# Altium schematic sheet 17-DPLL Outputs and Inputs.SchDoc("17-DPLL Outputs and Inputs")
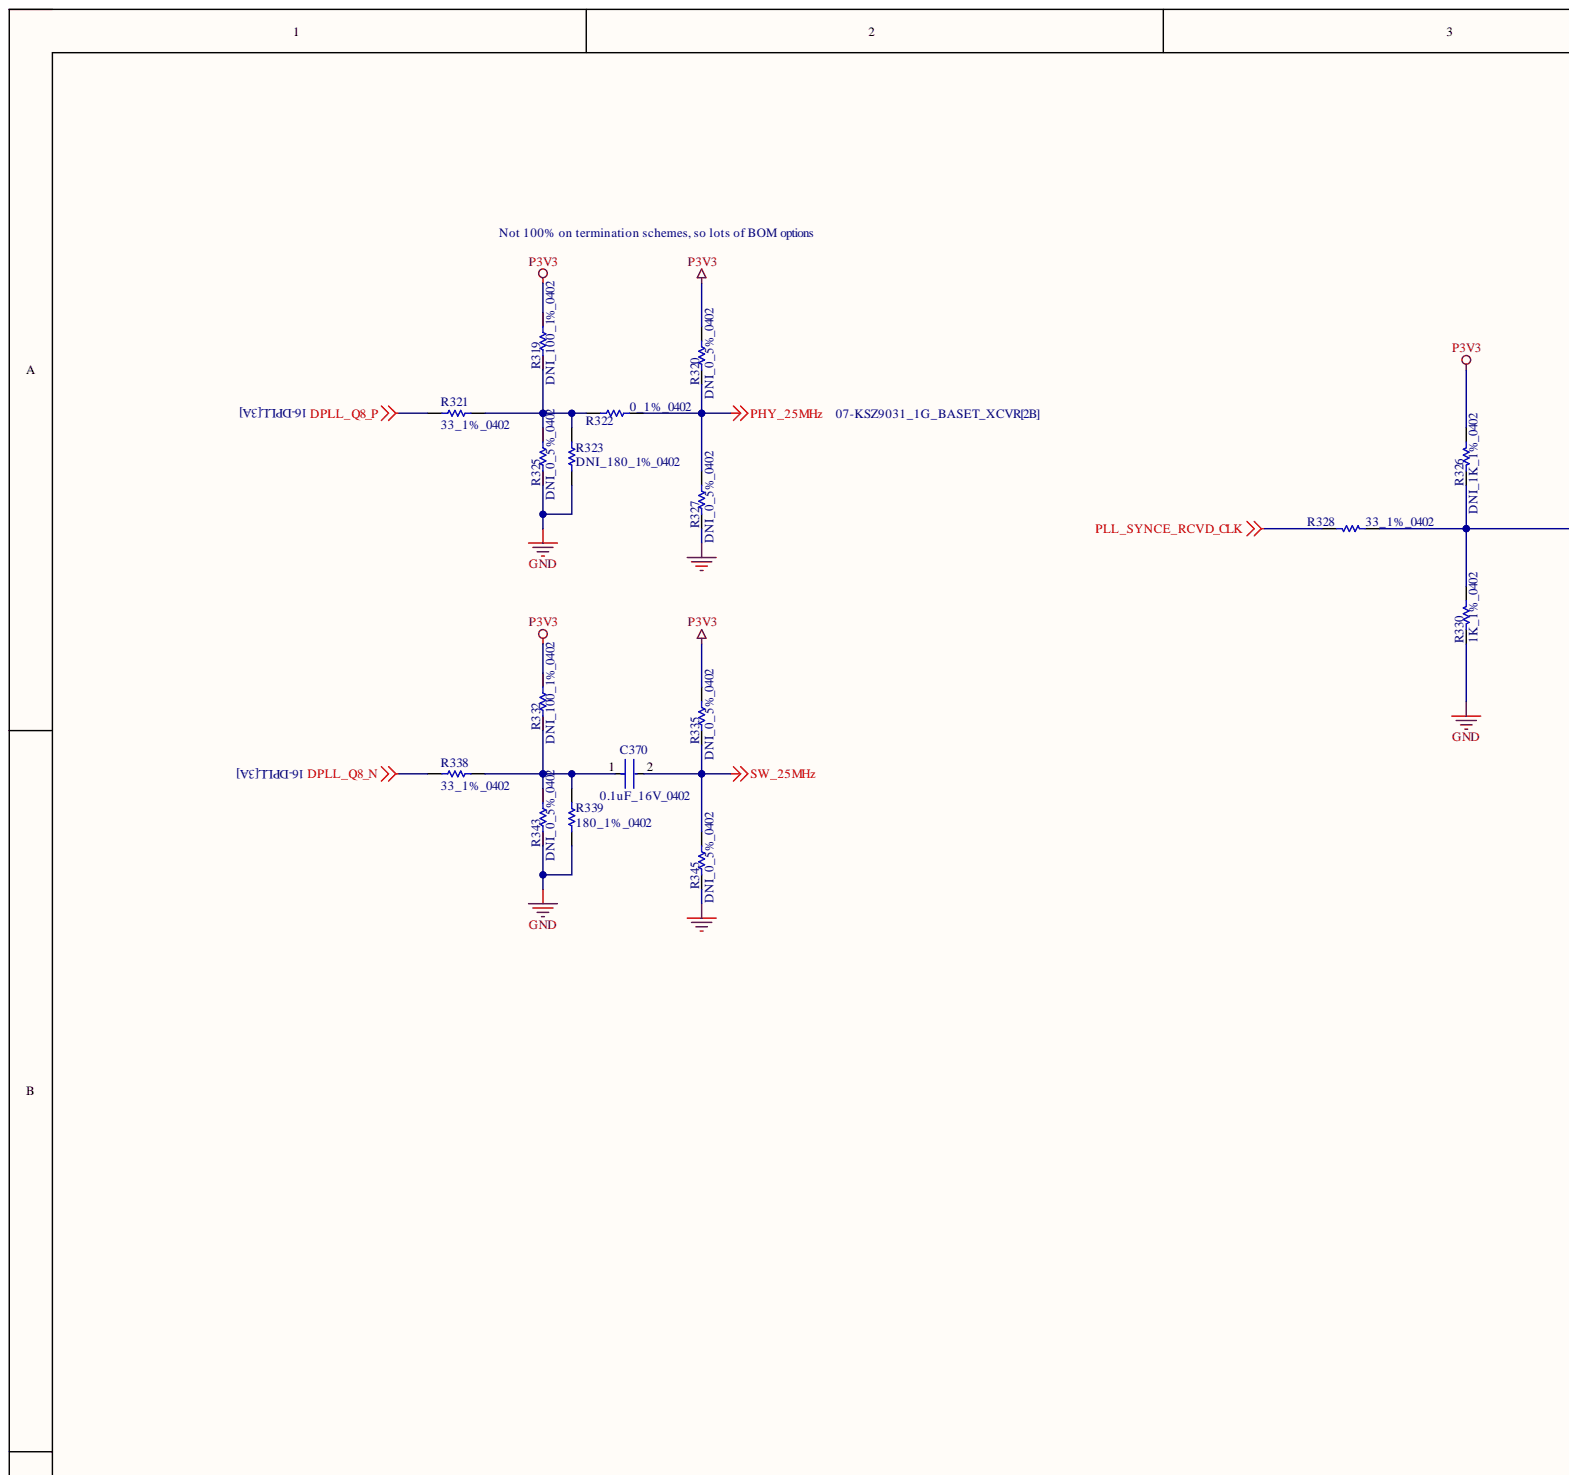
[diagram p0: 17-DPLL Outputs and Inputs.SchDoc, top left]
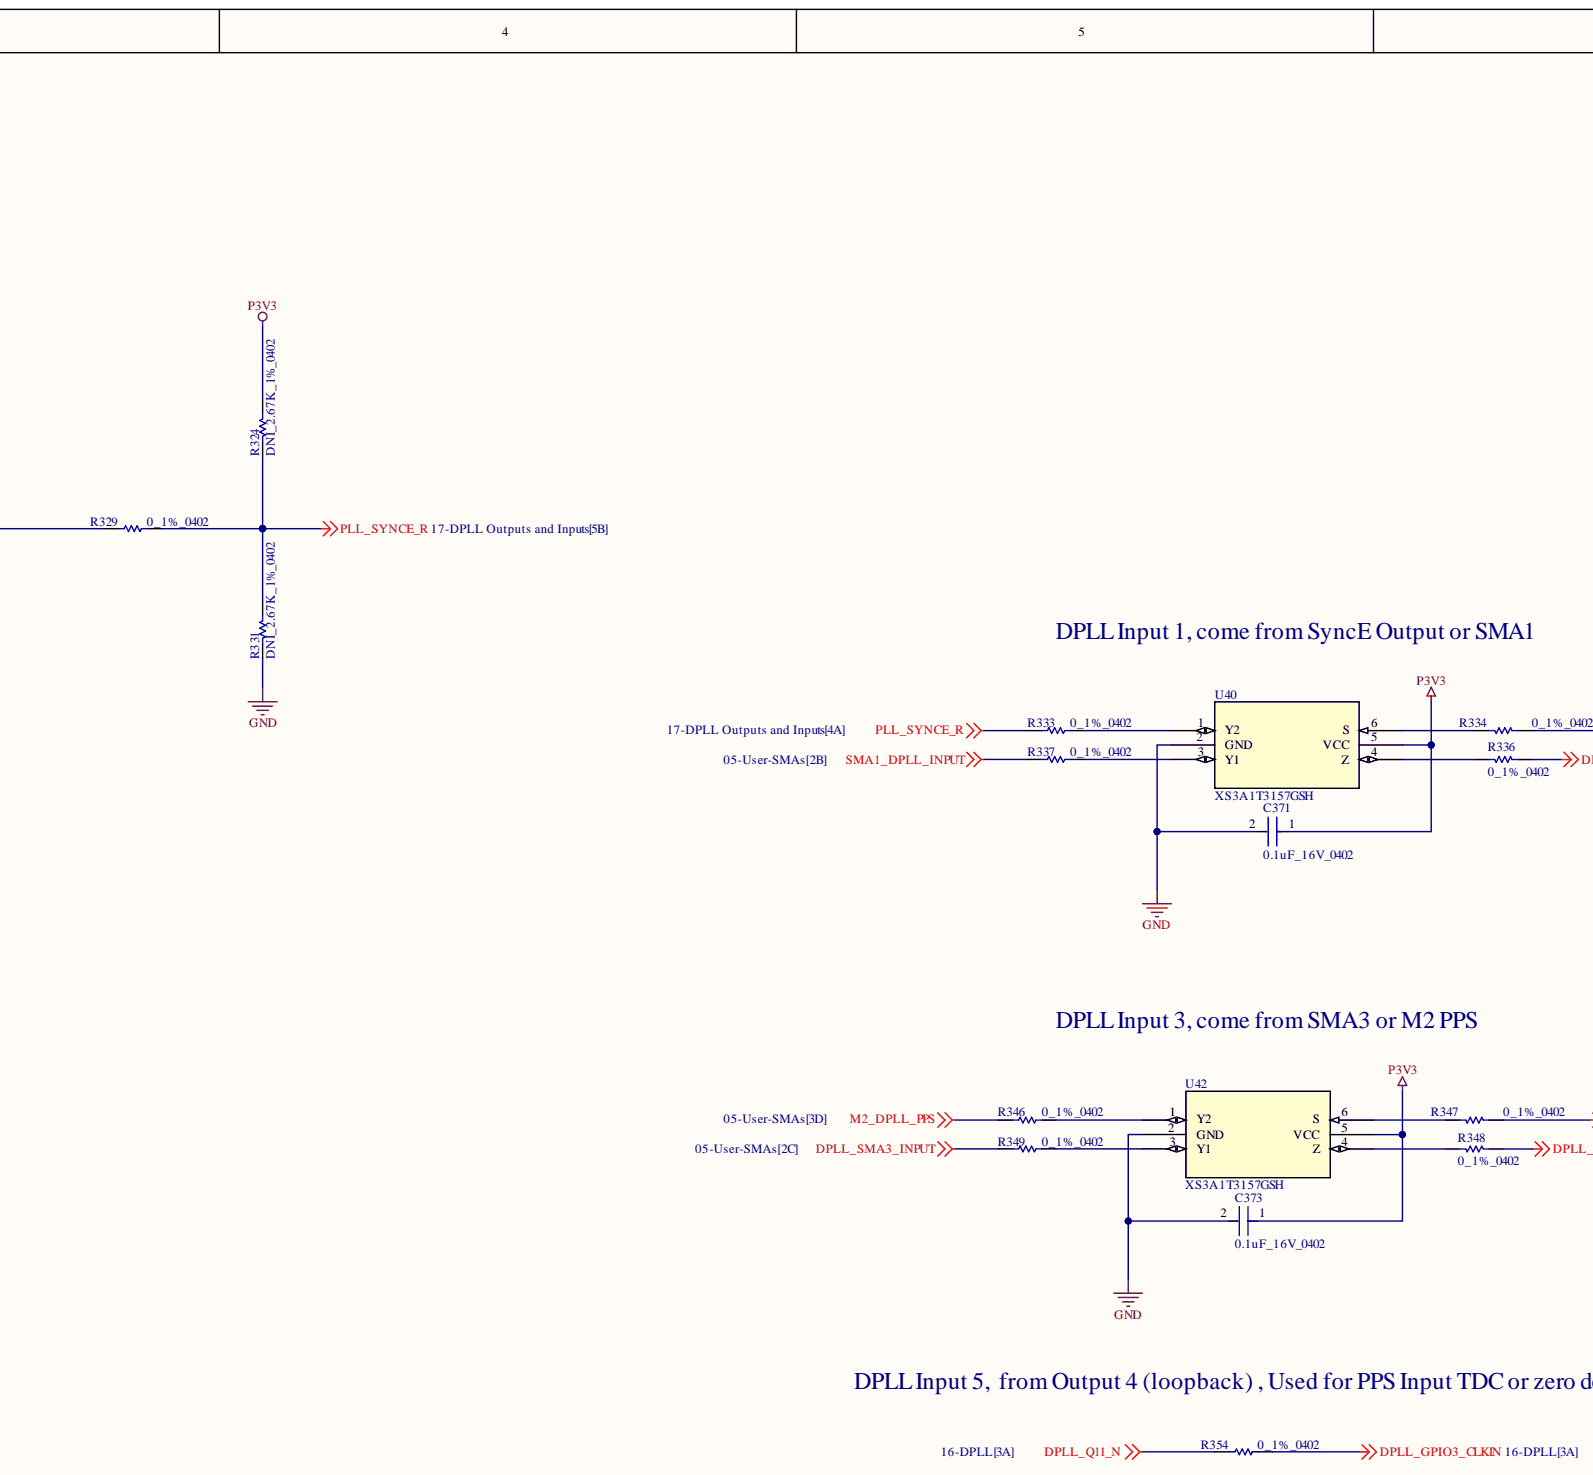
[diagram p1: 17-DPLL Outputs and Inputs.SchDoc, top centre]
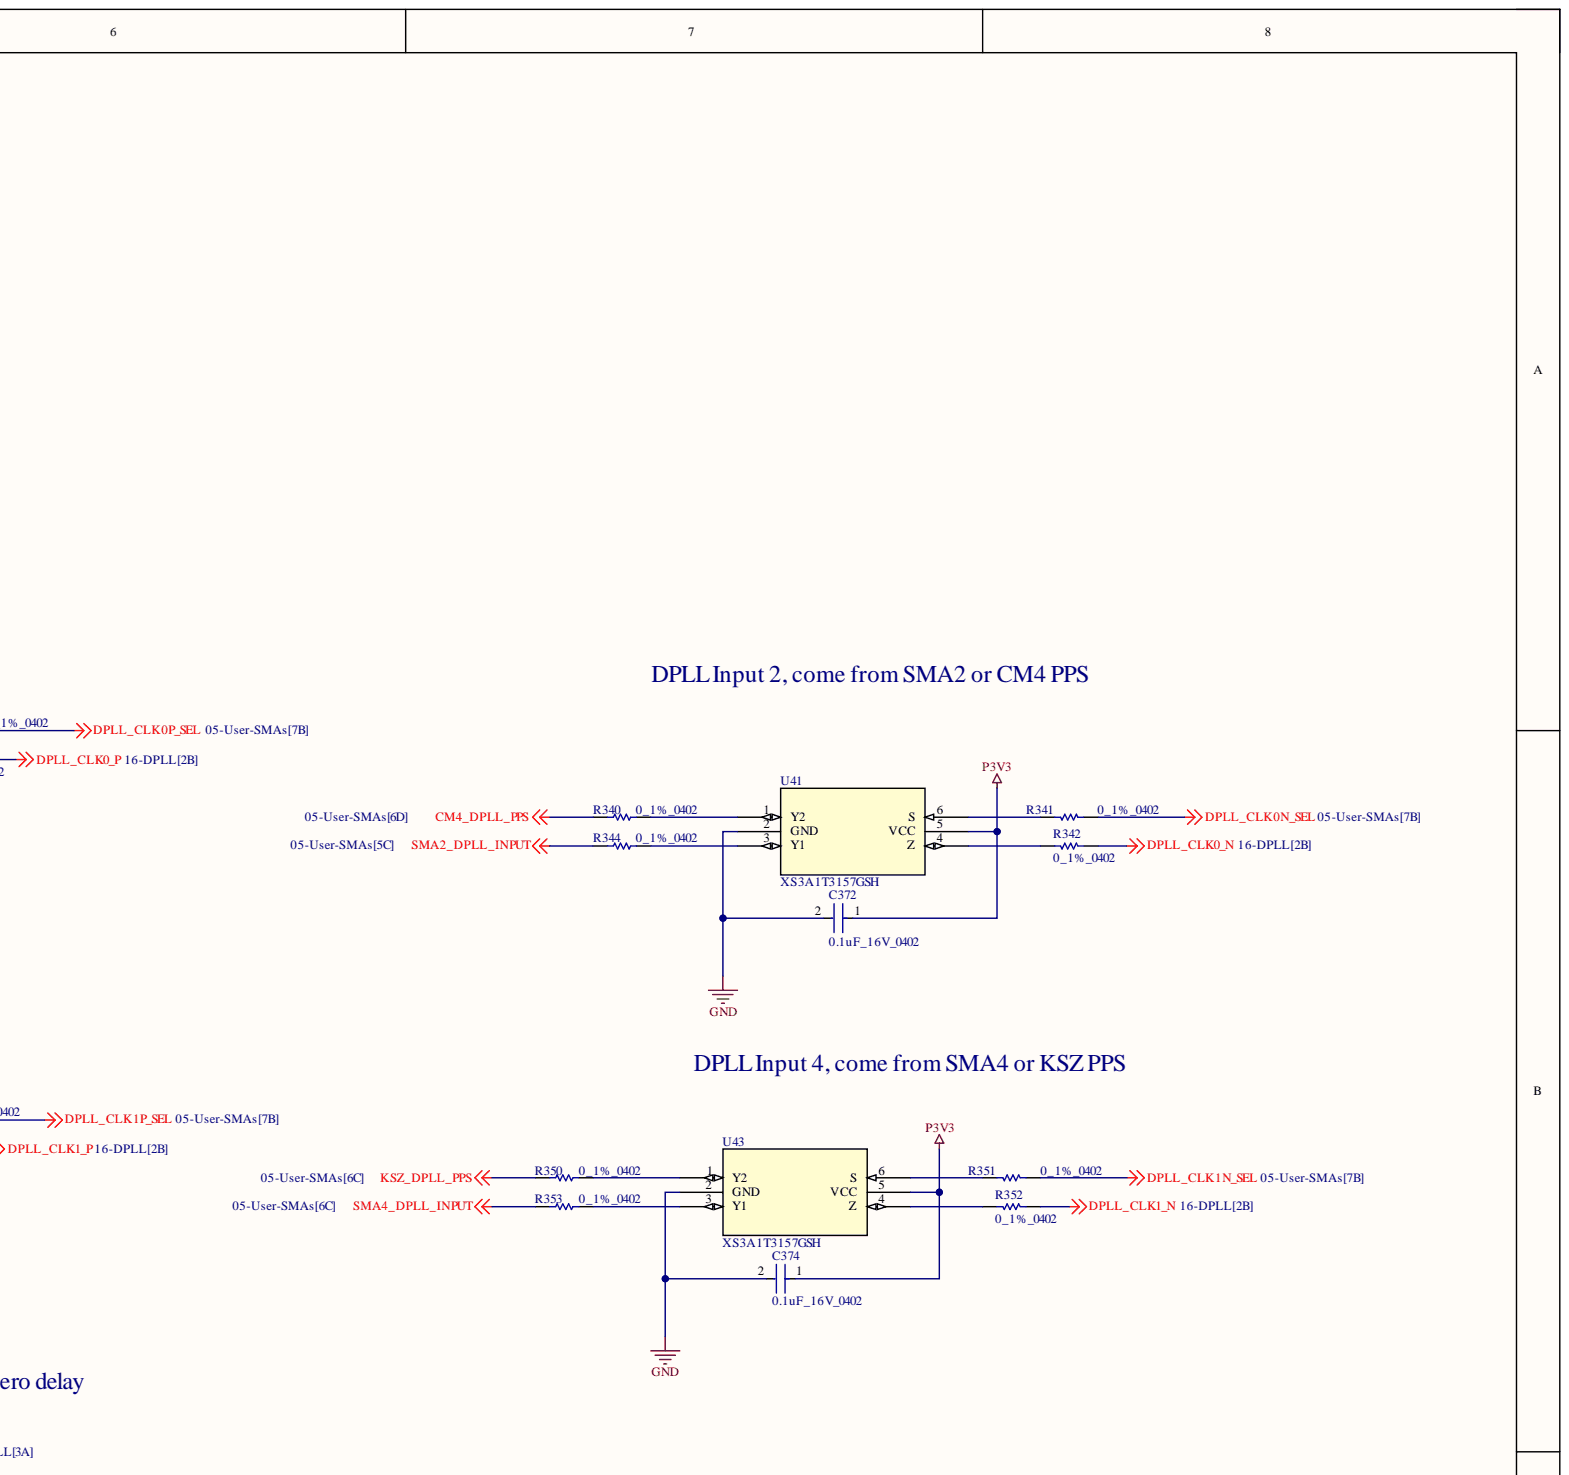
[diagram p2: 17-DPLL Outputs and Inputs.SchDoc, top right]
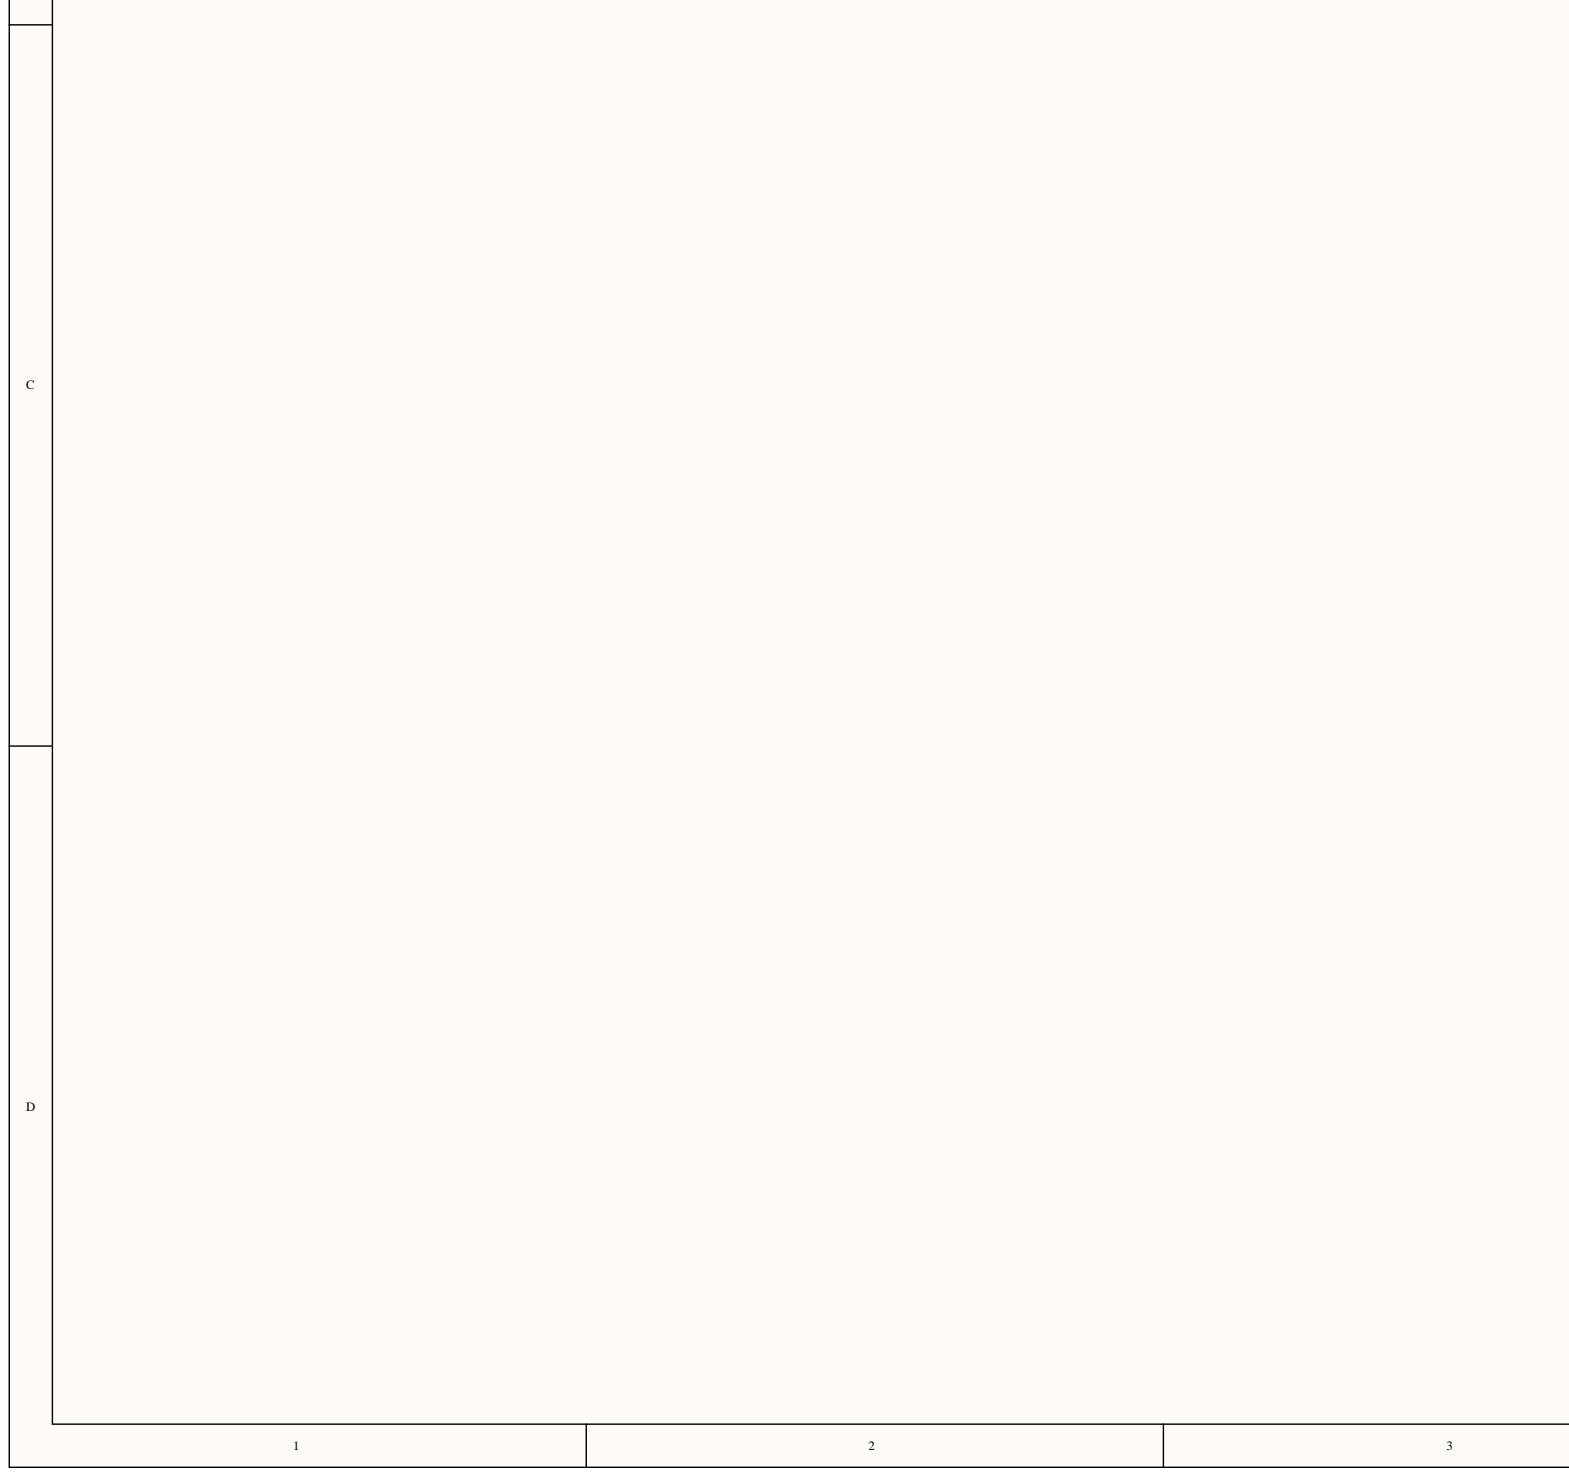
[diagram p3: 17-DPLL Outputs and Inputs.SchDoc, bottom left]
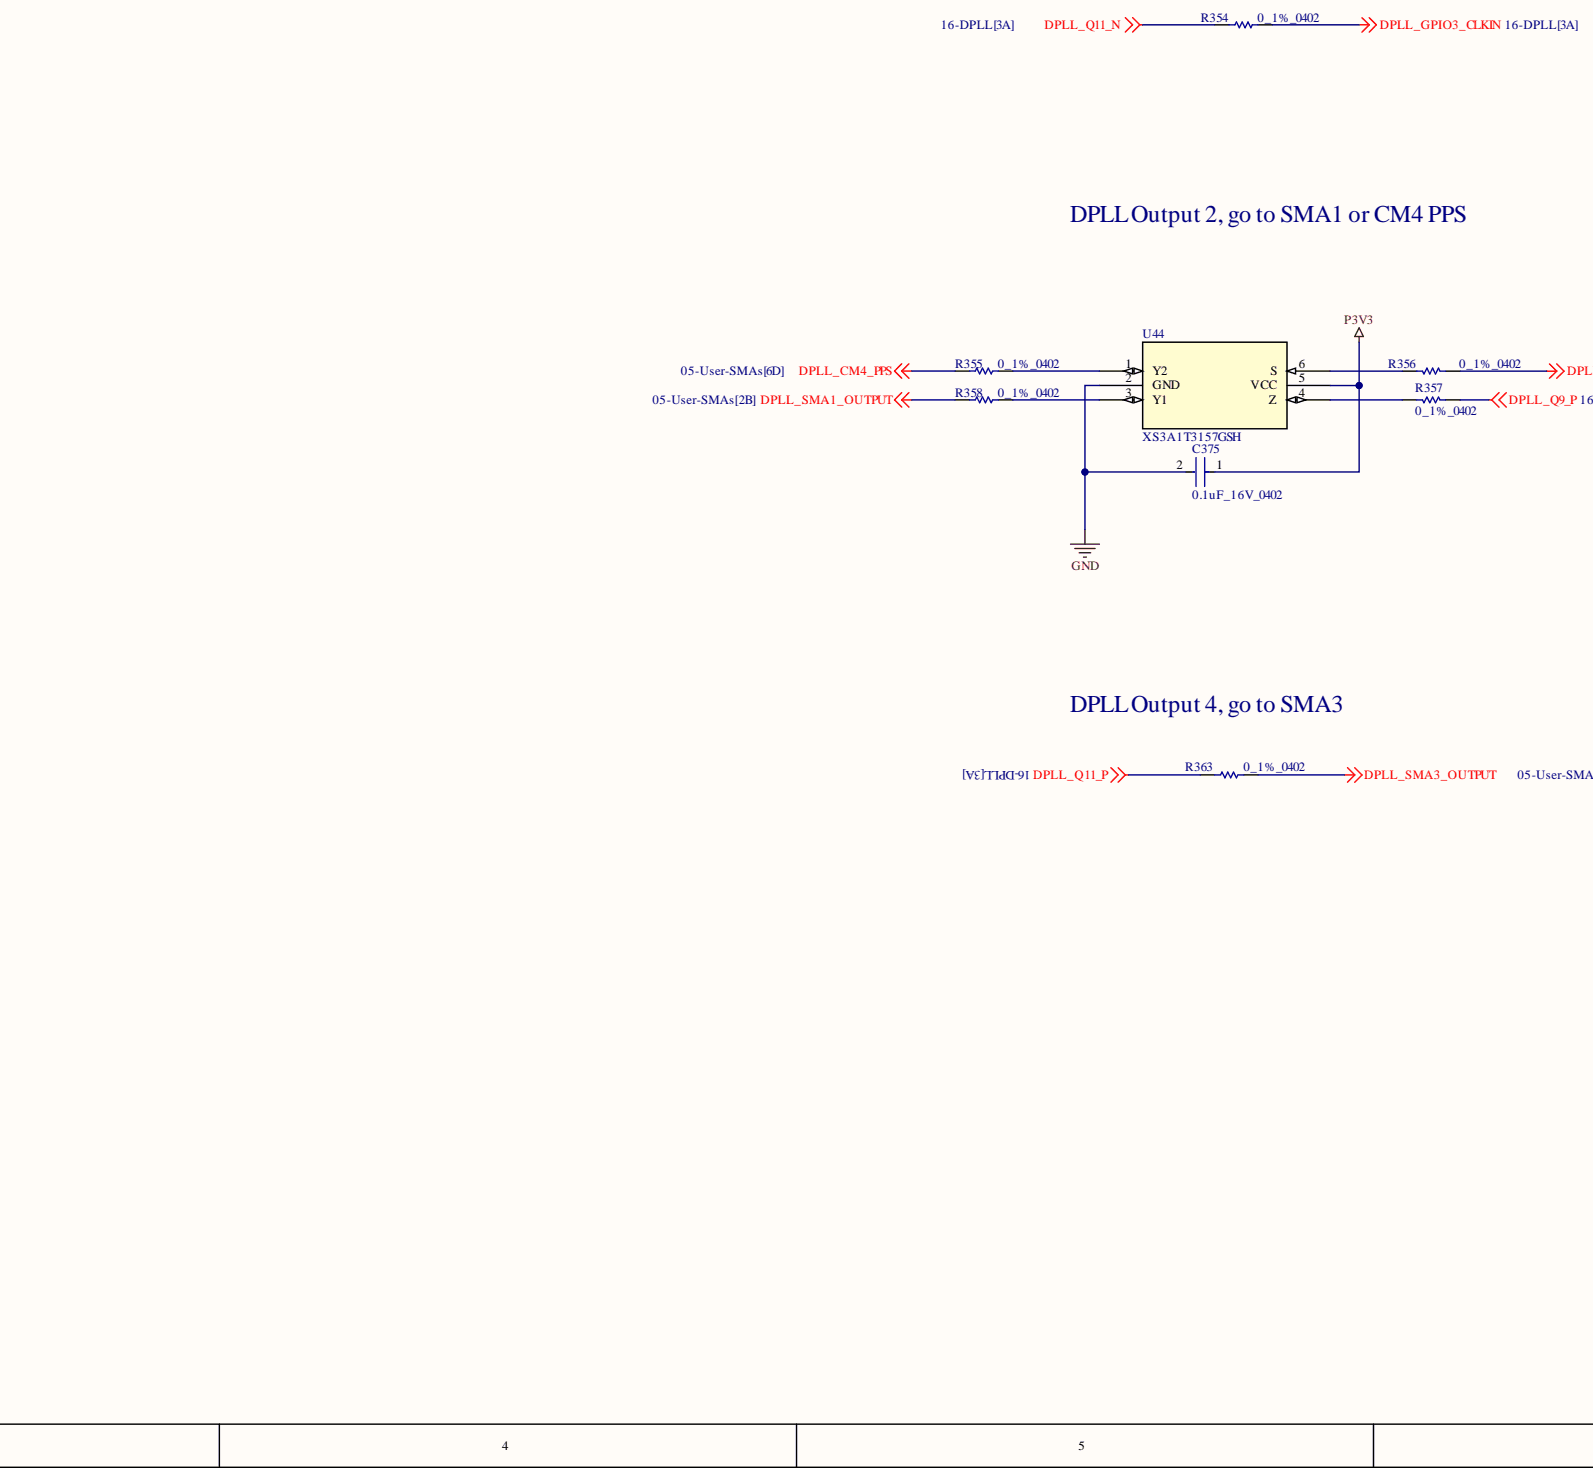
[diagram p4: 17-DPLL Outputs and Inputs.SchDoc, bottom centre]
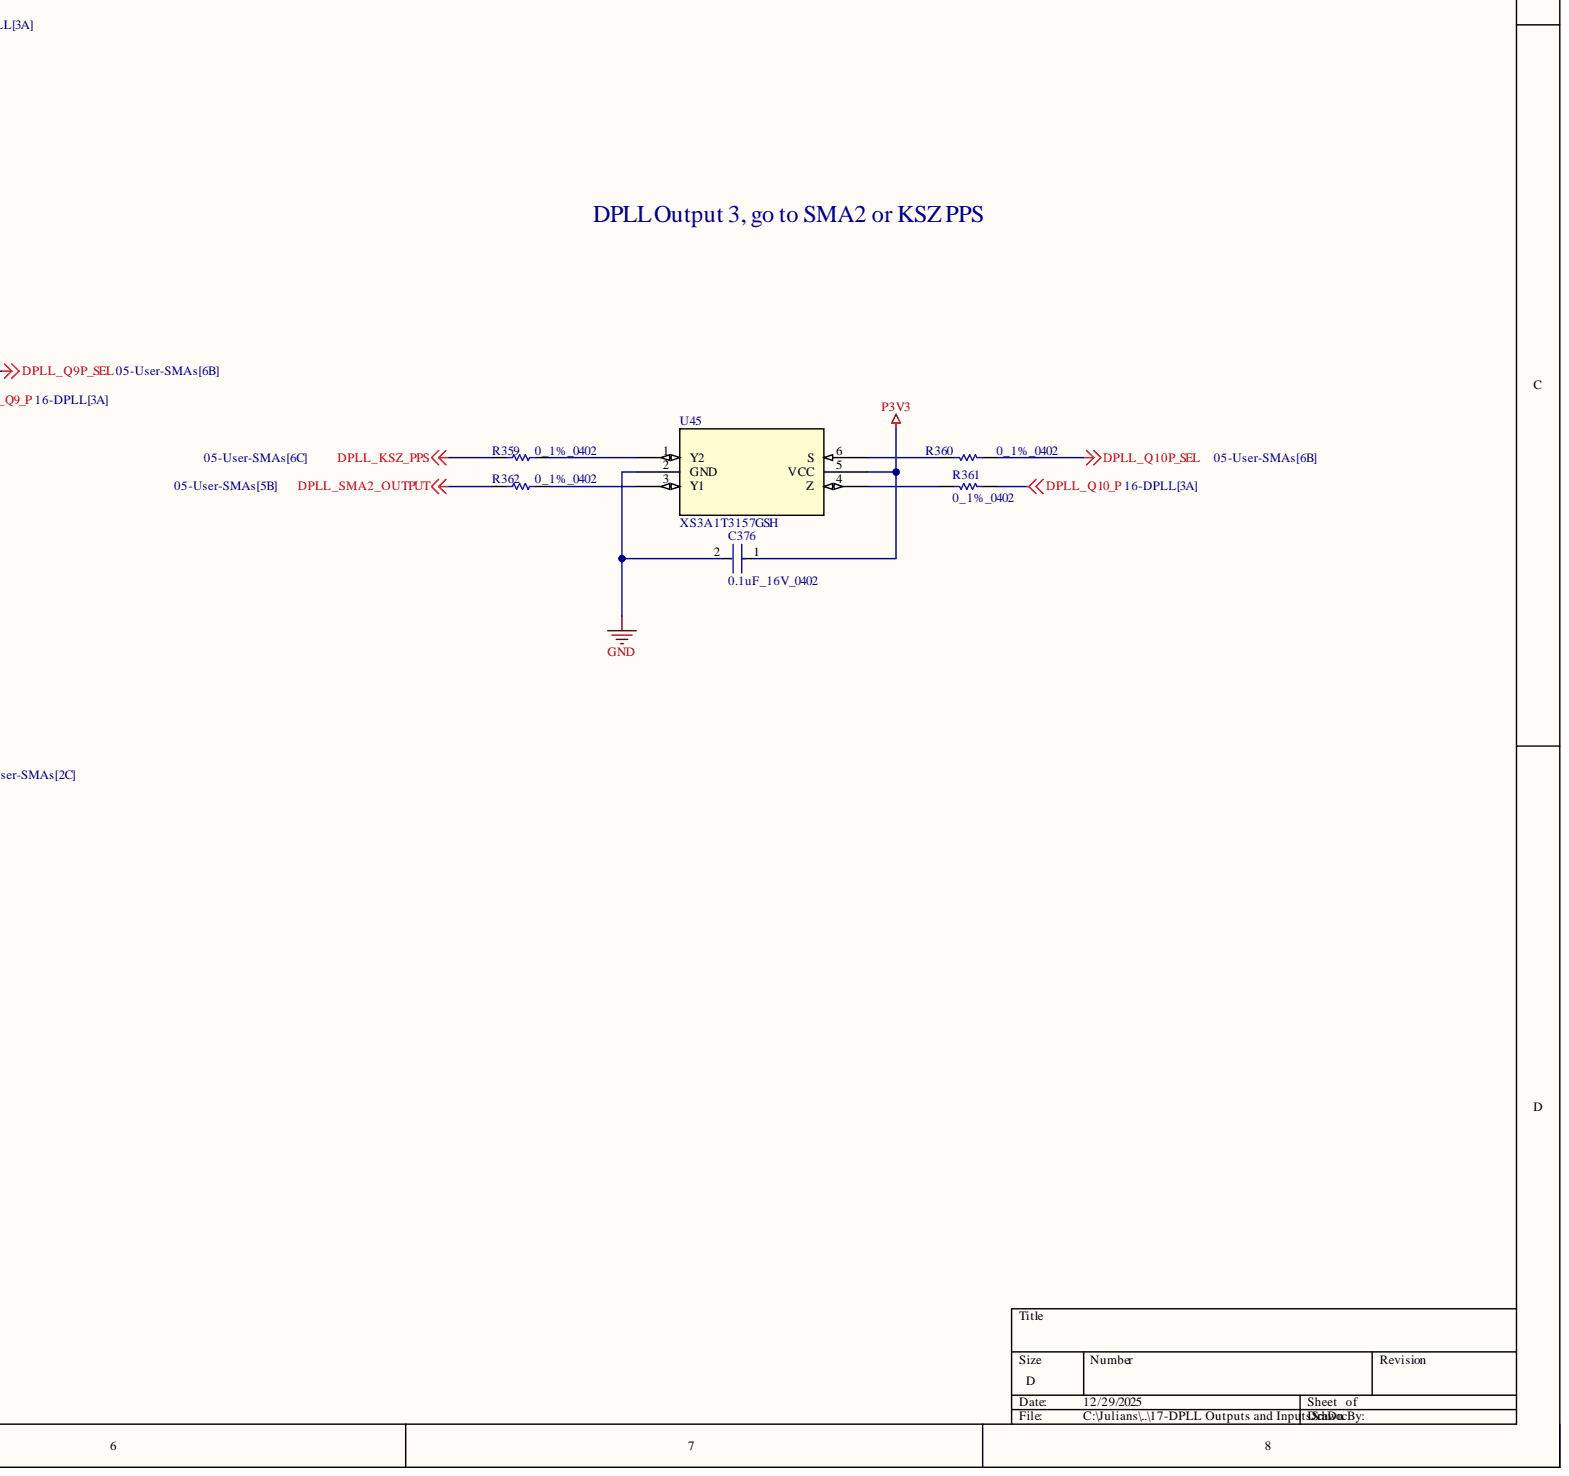
[diagram p5: 17-DPLL Outputs and Inputs.SchDoc, bottom right]

## Parts
C370  0.1uF_16V_0402  [FP-C0402-BB-MFG]
C371  0.1uF_16V_0402  [FP-C0402-BB-MFG]
C372  0.1uF_16V_0402  [FP-C0402-BB-MFG]
C373  0.1uF_16V_0402  [FP-C0402-BB-MFG]
C374  0.1uF_16V_0402  [FP-C0402-BB-MFG]
C375  0.1uF_16V_0402  [FP-C0402-BB-MFG]
C376  0.1uF_16V_0402  [FP-C0402-BB-MFG]
R319  DNI_100_1%_0402  [RESC1005X40X25ML05T10]
R320  DNI_0_5%_0402  [RESC1005X40X25NL05T10]  (no BOM)
R321  33_1%_0402  [RESC1005X40X25LL05T05]
R322  0_1%_0402  [RESC1005X40X25LL05T05]
R323  DNI_180_1%_0402  [RESC1005X40X25NL05T05]  (no BOM)
R324  DNI_2.67K_1%_0402  [RESC1005X40X25ML05T05]  (no BOM)
R325  DNI_0_5%_0402  [RESC1005X40X25NL05T10]  (no BOM)
R326  DNI_1K_1%_0402  [RESC1005X40X25ML05T10]  (no BOM)
R327  DNI_0_5%_0402  [RESC1005X40X25NL05T10]  (no BOM)
R328  33_1%_0402  [RESC1005X40X25LL05T05]
R329  0_1%_0402  [RESC1005X40X25LL05T05]
R330  1K_1%_0402  [RESC1005X40X25ML05T10]
R331  DNI_2.67K_1%_0402  [RESC1005X40X25ML05T05]  (no BOM)
R332  DNI_100_1%_0402  [RESC1005X40X25ML05T10]
R333  0_1%_0402  [RESC1005X40X25LL05T05]
R334  0_1%_0402  [RESC1005X40X25LL05T05]
R335  DNI_0_5%_0402  [RESC1005X40X25NL05T10]  (no BOM)
R336  0_1%_0402  [RESC1005X40X25LL05T05]
R337  0_1%_0402  [RESC1005X40X25LL05T05]
R338  33_1%_0402  [RESC1005X40X25LL05T05]
R339  180_1%_0402  [RESC1005X40X25NL05T05]
R340  0_1%_0402  [RESC1005X40X25LL05T05]
R341  0_1%_0402  [RESC1005X40X25LL05T05]
R342  0_1%_0402  [RESC1005X40X25LL05T05]
R343  DNI_0_5%_0402  [RESC1005X40X25NL05T10]  (no BOM)
R344  0_1%_0402  [RESC1005X40X25LL05T05]
R345  DNI_0_5%_0402  [RESC1005X40X25NL05T10]  (no BOM)
R346  0_1%_0402  [RESC1005X40X25LL05T05]
R347  0_1%_0402  [RESC1005X40X25LL05T05]
R348  0_1%_0402  [RESC1005X40X25LL05T05]
R349  0_1%_0402  [RESC1005X40X25LL05T05]
R350  0_1%_0402  [RESC1005X40X25LL05T05]
R351  0_1%_0402  [RESC1005X40X25LL05T05]
R352  0_1%_0402  [RESC1005X40X25LL05T05]
R353  0_1%_0402  [RESC1005X40X25LL05T05]
R354  0_1%_0402  [RESC1005X40X25LL05T05]
R355  0_1%_0402  [RESC1005X40X25LL05T05]
R356  0_1%_0402  [RESC1005X40X25LL05T05]
R357  0_1%_0402  [RESC1005X40X25LL05T05]
R358  0_1%_0402  [RESC1005X40X25LL05T05]
R359  0_1%_0402  [RESC1005X40X25LL05T05]
R360  0_1%_0402  [RESC1005X40X25LL05T05]
R361  0_1%_0402  [RESC1005X40X25LL05T05]
R362  0_1%_0402  [RESC1005X40X25LL05T05]
R363  0_1%_0402  [RESC1005X40X25LL05T05]
U40  XS3A1T3157GSH  [XSON6_SOT1202_NEX]
U41  XS3A1T3157GSH  [XSON6_SOT1202_NEX]
U42  XS3A1T3157GSH  [XSON6_SOT1202_NEX]
U43  XS3A1T3157GSH  [XSON6_SOT1202_NEX]
U44  XS3A1T3157GSH  [XSON6_SOT1202_NEX]
U45  XS3A1T3157GSH  [XSON6_SOT1202_NEX]

## Nets
CM4_DPLL_PPS: R340.1
DPLL_CLK0_N: R342.1
DPLL_CLK0_P: R336.1
DPLL_CLK0N_SEL: R341.1
DPLL_CLK0P_SEL: R334.1
DPLL_CLK1_N: R352.1
DPLL_CLK1_P: R348.1
DPLL_CLK1N_SEL: R351.1
DPLL_CLK1P_SEL: R347.1
DPLL_CM4_PPS: R355.1
DPLL_GPIO3_CLKIN: R354.2
DPLL_KSZ_PPS: R359.1
DPLL_Q8_N: R338.1
DPLL_Q8_P: R321.1
DPLL_Q9_P: R357.1
DPLL_Q9P_SEL: R356.1
DPLL_Q10_P: R361.1
DPLL_Q10P_SEL: R360.1
DPLL_Q11_N: R354.1
DPLL_Q11_P: R363.1
DPLL_SMA1_OUTPUT: R358.1
DPLL_SMA2_OUTPUT: R362.1
DPLL_SMA3_INPUT: R349.1
DPLL_SMA3_OUTPUT: R363.2
GND: C371.2 C372.2 C373.2 C374.2 C375.2 C376.2 R323.1 R325.1 R327.1 R330.1 R331.1 R339.1 R343.1 R345.1 U40.2(GND) U41.2(GND) U42.2(GND) U43.2(GND) U44.2(GND) U45.2(GND)
KSZ_DPLL_PPS: R350.1
M2_DPLL_PPS: R346.1
P3V3: C371.1 C372.1 C373.1 C374.1 C375.1 C376.1 R319.2 R320.2 R324.2 R326.2 R332.2 R335.2 U40.5(VCC) U41.5(VCC) U42.5(VCC) U43.5(VCC) U44.5(VCC) U45.5(VCC)
PHY_25MHz: R320.1 R322.2 R327.2
PLL_SYNCE_R: R324.1 R329.2 R331.2 R333.1
PLL_SYNCE_RCVD_CLK: R328.2
SMA1_DPLL_INPUT: R337.1
SMA2_DPLL_INPUT: R344.1
SMA4_DPLL_INPUT: R353.1
SW_25MHz: C370.2 R335.1 R345.2
NetC370_1: C370.1 R332.1 R338.2 R339.2 R343.2
NetR319_1: R319.1 R321.2 R322.1 R323.2 R325.2
NetR326_1: R326.1 R328.1 R329.1 R330.2
NetR333_2: R333.2 U40.1(Y2)
NetR334_2: R334.2 U40.6(S)
NetR336_2: R336.2 U40.4(Z)
NetR337_2: R337.2 U40.3(Y1)
NetR340_2: R340.2 U41.1(Y2)
NetR341_2: R341.2 U41.6(S)
NetR342_2: R342.2 U41.4(Z)
NetR344_2: R344.2 U41.3(Y1)
NetR346_2: R346.2 U42.1(Y2)
NetR347_2: R347.2 U42.6(S)
NetR348_2: R348.2 U42.4(Z)
NetR349_2: R349.2 U42.3(Y1)
NetR350_2: R350.2 U43.1(Y2)
NetR351_2: R351.2 U43.6(S)
NetR352_2: R352.2 U43.4(Z)
NetR353_2: R353.2 U43.3(Y1)
NetR355_2: R355.2 U44.1(Y2)
NetR356_2: R356.2 U44.6(S)
NetR357_2: R357.2 U44.4(Z)
NetR358_2: R358.2 U44.3(Y1)
NetR359_2: R359.2 U45.1(Y2)
NetR360_2: R360.2 U45.6(S)
NetR361_2: R361.2 U45.4(Z)
NetR362_2: R362.2 U45.3(Y1)

## Library data of the parts
C370-C376 = CMP-1034-02068-3: CAP CER 0.1UF 16V 5% X7R 0402; Manufacturer: Kemet; Manufacturer Part Number: C0402C104J4RACTU; Capacitance: 0.10 μF; Material: Ceramic; Temperature Coefficient: 15%; Tolerance: 0.05; Value: 0.10 μF; Automotive: No; Category: Cap; Component Kind: Standard; ComponentLink2Description: Datasheet; ComponentLink2URL: https://content.kemet.com/datasheets/KEM_C1002_X7R_SMD.pdf; Device Class L1: Passive Components; Device Class L2: Capacitors; Device Class L3: Ceramic Capacitors; Digikey Description: CAP CER 0.1UF 16V 5% X7R 0402; Height: 0.55mm; Ipc Land Pattern Name: CAPC10050X50; Lead Free: Yes; Library Name: Altium Content Vault; Mouser Description: CAP CER 0.1UF 16V X7R 0402; Octopart: https://octopart.com/c0402c104j4ractu-kemet-12573893; Package: C0402-BB; RoHS: TRUE; Temperature: -55°C to +125°C; Temperature Characteristic: X7R; Temperature Range High: +125°C; Temperature Range Low: -55°C; Voltage Rating: 16 V
R319 R332 = CMP-2002-07344-1: Manufacturer: Yageo / Phycomp; Part Number: RC0402FR-07100RL; Component Kind: Standard; Library Name: Altium Content Vault
R320 R325 R327 R335 R343 R345 = CMP-2002-06457-1: Manufacturer: Panasonic; Part Number: ERJ-U020R00X; Library Name: Altium Content Vault
R321 R338 = CMP-2002-01146-1: Manufacturer: Panasonic; Part Number: ERJ2RKF33R0X; Component Kind: Standard; Library Name: Altium Content Vault
R322 R329 R333 R334 R336 R337 R340-R342 R344 R346-R363 = CMP-2000-07451-1: Chip Resistor, 0 Ohm, 0.1 W, -55 to 155 degC, 0402 (1005 Metric), RoHS, Tape and Reel; Manufacturer: Panasonic; Max Power Dissipation: 100mW; Resistance: 0mOhm; Temperature Coefficient: 600ppm/��C; Tolerance: 5%; Voltage Rating: 50V; Case Code (Imperial): 0402; Case Code (Metric): 1005; Case/Package: 0402; Component Kind: Standard; Composition: ThickFilm; Contact Plating: Tin; Datasheet URL: https://industrial.panasonic.com/cdbs/www-data/pdf/RDA0000/AOA0000C301.pdf; Datasheet Version: 03/2015; Depth: 0.5mm; Height: 0.35mm; Lead Free: LeadFree; Length: 1mm; Library Name: Altium Content Vault; Manufacturer URL: http://www.panasonic.com/; Max Operating Temperature: 155degC; Military Standard: Not; Min Operating Temperature: -55degC; Mount: SurfaceMount; Mounting Technology: SurfaceMount; Number of Terminations: 2; Package Description: 2-Pin Surface Mount Device, Body 1 x 0.5 mm; Package Quantity: 1; Package Reference: 402; Packaging: TapeandReel; Power Rating: 100mW; Radiation Hardening: No; REACH SVHC: NoSVHC; RoHSCompliant: True; Termination: SMD/SMT; Voltage Rating (DC): 50V; Width: 0.02inch
R323 R339 = CMP-2002-01127-1: Manufacturer: Panasonic; Part Number: ERJ2RKF1800X; Library Name: Altium Content Vault
  R339: Component Kind: Standard
R324 R331 = CMP-1011-00556-2: Manufacturer: Panasonic; Part Number: ERJ-2RKF2671X; Library Name: Altium Content Vault
R326 R330 = CMP-2002-06478-1: Manufacturer: Multicomp; Part Number: MCMR04X1001FTL; Library Name: Altium Content Vault
  R330: Component Kind: Standard
R328 = CMP-1011-00341-2: Manufacturer: Vishay Dale; Part Number: CRCW040233R0FKTD; Component Kind: Standard; Library Name: Altium Content Vault
U40-U45 = XS3A1T3157GSH: No Description Available; Manufacturer_Name: Nexperia; Component Kind: Standard; Library Name: XS3A1T3157GSH.SchLib; Manufacturer_Part_Number: XS3A1T3157GSH; RefDes: RefDes; Type: Interface; Copyright: Copyright (C) 2025 Ultra Librarian. All rights reserved.
